# Altium schematic sheet LoRa_Elemon_1.SchDoc(LoRa_Elemon_1)
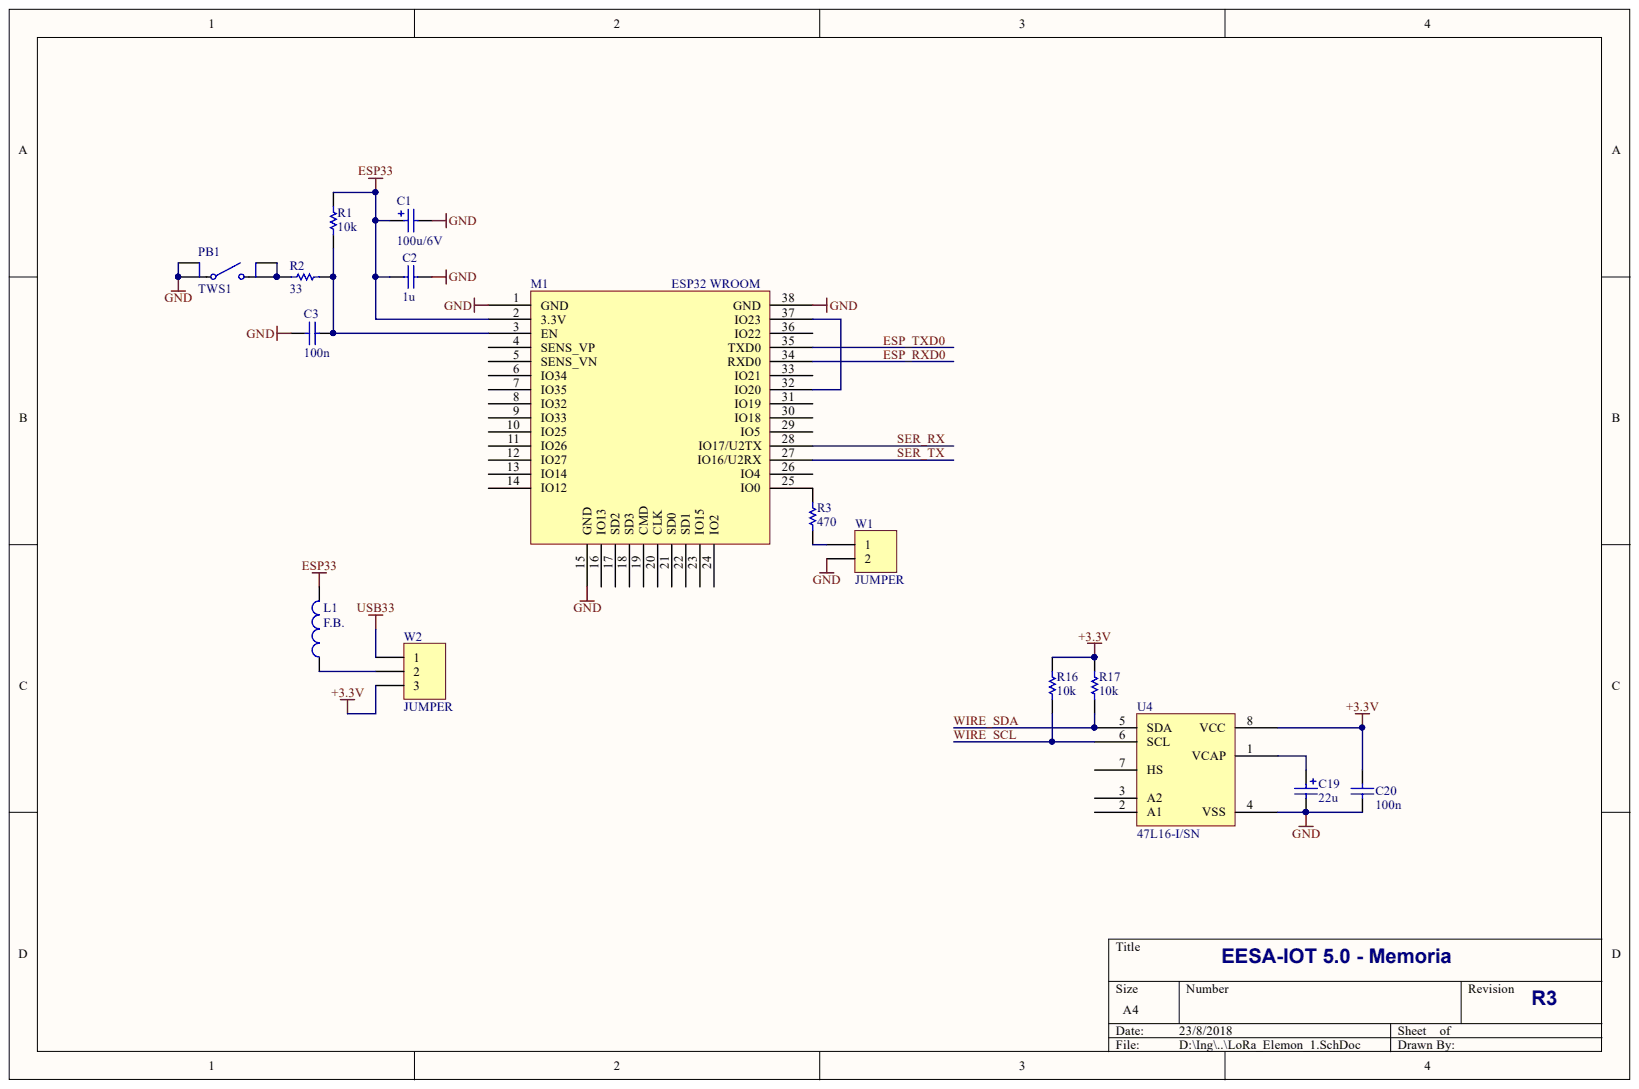
[diagram p0: LoRa_Elemon_1.SchDoc]

## Parts
C1  100u/6V  [ELEC-MINI-5]
C2  1u  [0805]
C3  100n  [0805]
C19  22u  [ELEC-MINI-5]
C20  100n  [0805]
L1  F.B.  [0805]
M1  ESP32 WROOM  [ESP32_WROOM]
PB1  TWS1  [TACWS1]
R1  10k  [0805]
R2  33  [0805]
R3  470  [0805]
R16  10k  [0805]
R17  10k  [0805]
U4  47L16-I/SN  [SO-8]
W1  JUMPER  [HDR1X2]
W2  JUMPER  [HDR1X3]

## Nets
+3.3V: C20.1 R16.2 R17.2 U4.8(VCC) W2.3
ESP33: C1.1 C2.2 L1.1 M1.2(3.3V) R1.2
GND: C1.2 C2.1 C3.2 C19.2 C20.2 M1.1(GND) M1.15(GND) M1.38(GND) PB1.1 PB1.3 U4.4(VSS) W1.2
USB33: W2.1
ESP_RXD0: M1.34(RXD0)
ESP_TXD0: M1.35(TXD0)
SER_RX: M1.28(IO17/U2TX)
SER_TX: M1.27(IO16/U2RX)
WIRE_SCL: R16.1 U4.6(SCL)
WIRE_SDA: R17.1 U4.5(SDA)
NetC3_1: C3.1 M1.3(EN) R1.1 R2.1
NetC19_1: C19.1 U4.1(VCAP)
NetL1_2: L1.2 W2.2
NetM1_25: M1.25(IO0) R3.2
NetM1_32: M1.32(IO20) M1.37(IO23)
NetPB1_2: PB1.2 PB1.4 R2.2
NetR3_1: R3.1 W1.1

Not connected: M1 4(SENS_VP) 5(SENS_VN) 6(IO34) 7(IO35) 8(IO32) 9(IO33) 10(IO25) 11(IO26) 12(IO27) 13(IO14) 14(IO12) 16(IO13) 17(SD2) 18(SD3) 19(CMD) 20(CLK) 21(SD0) 22(SD1) 23(IO15) 24(IO2) 26(IO4) 29(IO5) 30(IO18) 31(IO19) 33(IO21) 36(IO22); U4 2(A1) 3(A2) 7(HS)

## Library data of the parts
C1 = Cap Pol1: Electrolitico mini 5mm; Value: 100pF; Component Kind: Standard; Library Name: Miscellaneous Devices.IntLib; Published: 8-Jun-2000; Revision: July-2002: Re-released for DXP Platform.; Signal Integrity: Cap Pol; Simulation: CAP; Publisher: Altium Limited
C2 C3 = Cap: Capacitor; Component Kind: Standard; LatestRevisionDate: 17-Jul-2002; LatestRevisionNote: Re-released for DXP Platform.; Library Name: Miscellaneous Devices.IntLib; PackageDescription: Radial Cap, Thru-Hole; 2 Leads; 0.3 in Pin Spacing; PackageReference: RAD-0.3; Published: 8-Jun-2000; Signal Integrity: Cap; Simulation: CAP; Publisher: Altium Limited
C19 = Cap Pol2: Electrolitico mini 5mm; Value: 100pF; Component Kind: Standard; LatestRevisionDate: 17-Jul-2002; LatestRevisionNote: Re-released for DXP Platform.; Library Name: Miscellaneous Devices.IntLib; PackageDescription: Polarized Capacitor; 2 Leads; PackageReference: POLAR0.8; Published: 8-Jun-2000; Signal Integrity: Cap Pol; Simulation: CAP; Publisher: Altium Limited
C20 = Cap: Capacitor; Value: 10nF; Component Kind: Standard; Library Name: Miscellaneous Devices.IntLib; Published: 8-Jun-2000; Revision: July-2002: Re-released for DXP Platform.; Signal Integrity: Cap; Simulation: CAP; Publisher: Altium Limited
L1 = Inductor: Code_IEC: 1005; Value: 10mH; Code_JEITA: 0402; Component Kind: Standard; Description: Inductor; LatestRevisionDate: 29-May-2009; LatestRevisionNote: IPC-7351 Footprint Added.; Library Name: Miscellaneous Devices.IntLib; PackageDescription: Chip Inductor; PackageReference: 0402-A; Published: 8-Jun-2000; Signal Integrity: Inductor; Simulation: INDUCTOR; Publisher: Altium Limited
M1 = ESP32_WROOM: Component Kind: Standard; Library Name: LoRa_Elemon.SchLib
R1 R3 R16 R17 = Res1: Resistor SMD 0805; Value: 1K; Component Kind: Standard; LatestRevisionDate: 17-Jul-2002; LatestRevisionNote: Re-released for DXP Platform.; Library Name: Miscellaneous Devices.IntLib; PackageDescription: Axial Device, Thru-Hole; 2 Leads; 0.3 in Pin Spacing; PackageReference: AXIAL-0.3; Published: 8-Jun-2000; Signal Integrity: Res; Simulation: RESISTOR; Publisher: Altium Limited
R2 = Res1: Resistor; Value: 1K; Component Kind: Standard; LatestRevisionDate: 17-Jul-2002; LatestRevisionNote: Re-released for DXP Platform.; Library Name: Miscellaneous Devices.IntLib; PackageDescription: Axial Device, Thru-Hole; 2 Leads; 0.3 in Pin Spacing; PackageReference: AXIAL-0.3; Published: 8-Jun-2000; Signal Integrity: Res; Simulation: RESISTOR; Publisher: Altium Limited
U4 = 47C16: Memory, EERAM; Component Kind: Standard; Library Name: lav3.SchLib
W1 = Header 2: Header, 2-Pin; Component Kind: Standard; LatestRevisionDate: 17-Jul-2002; LatestRevisionNote: Re-released for DXP Platform.; Library Name: Miscellaneous Connectors.IntLib; Signal Integrity: Connector; Publisher: Altium Limited
W2 = Header 3: Header, 3-Pin; Component Kind: Standard; LatestRevisionDate: 17-Jul-2002; LatestRevisionNote: Re-released for DXP Platform.; Library Name: Miscellaneous Connectors.IntLib; Signal Integrity: Connector; Publisher: Altium Limited
PB1 = TWS1: Component Kind: Standard; Library Name: LoRa_Elemon.SchLib
